# Altium schematic sheet QuadDrv405.SchDoc(QuadDrv405)
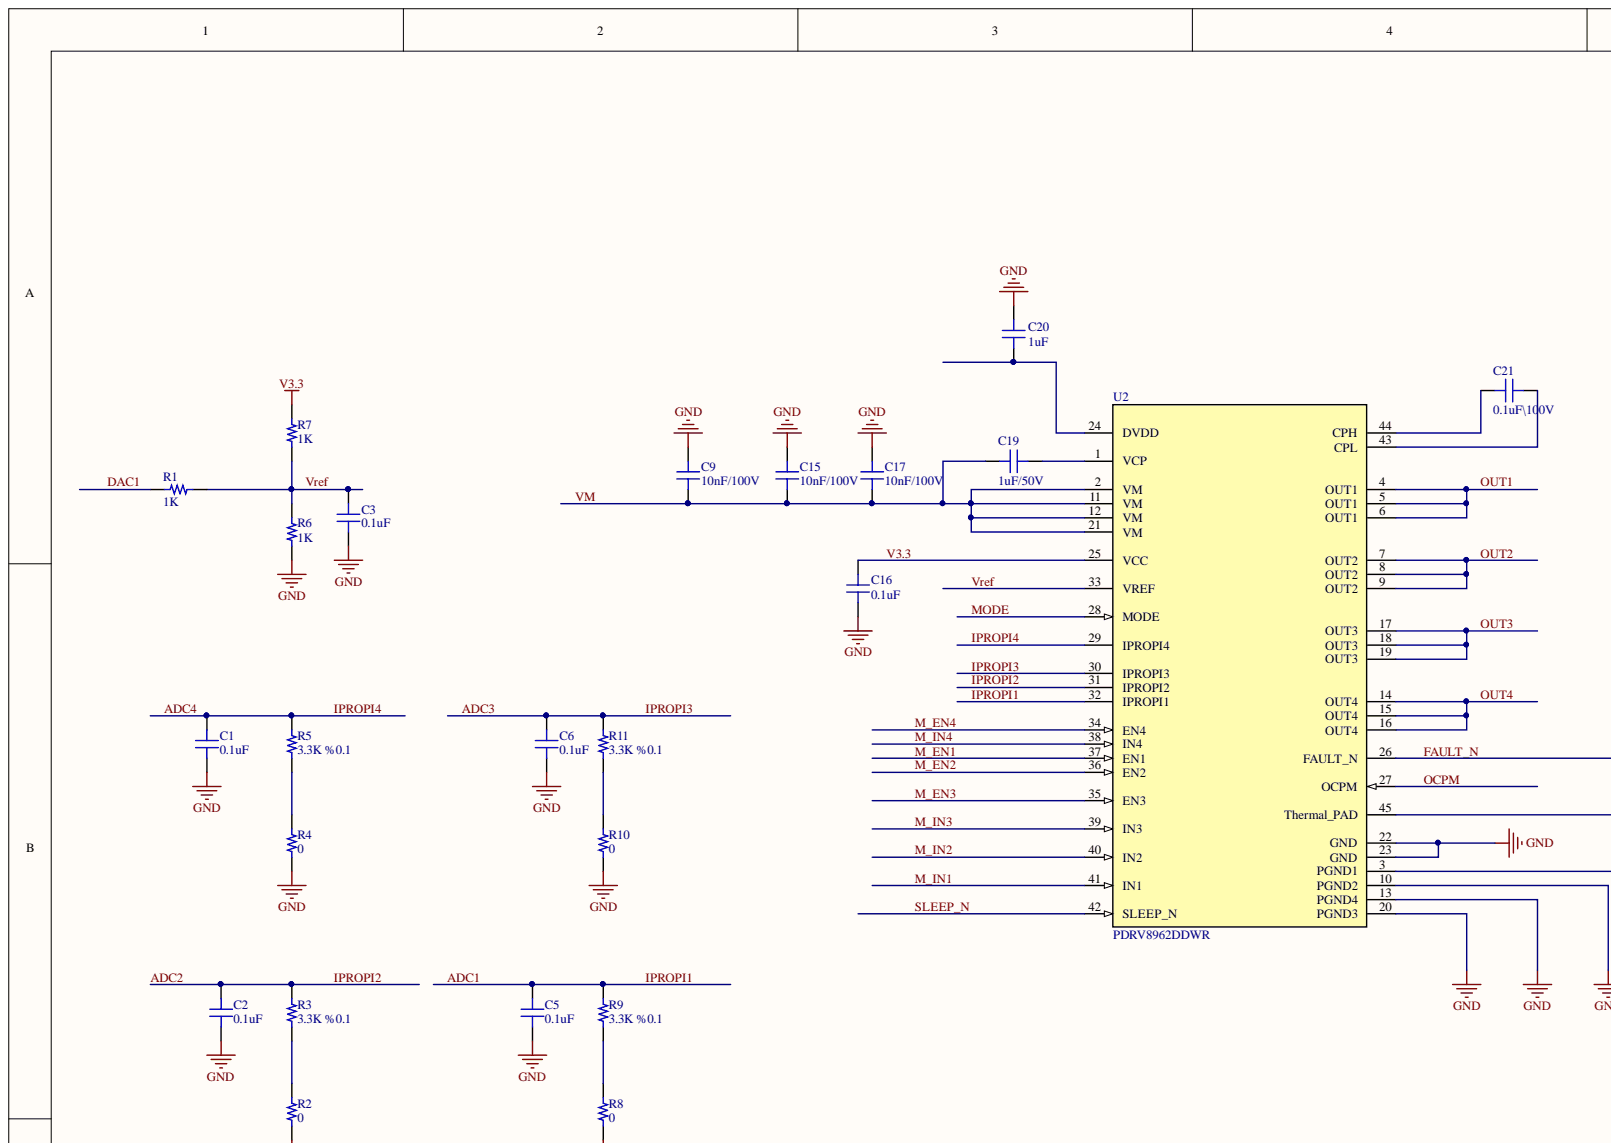
[diagram p0: QuadDrv405.SchDoc, top left]
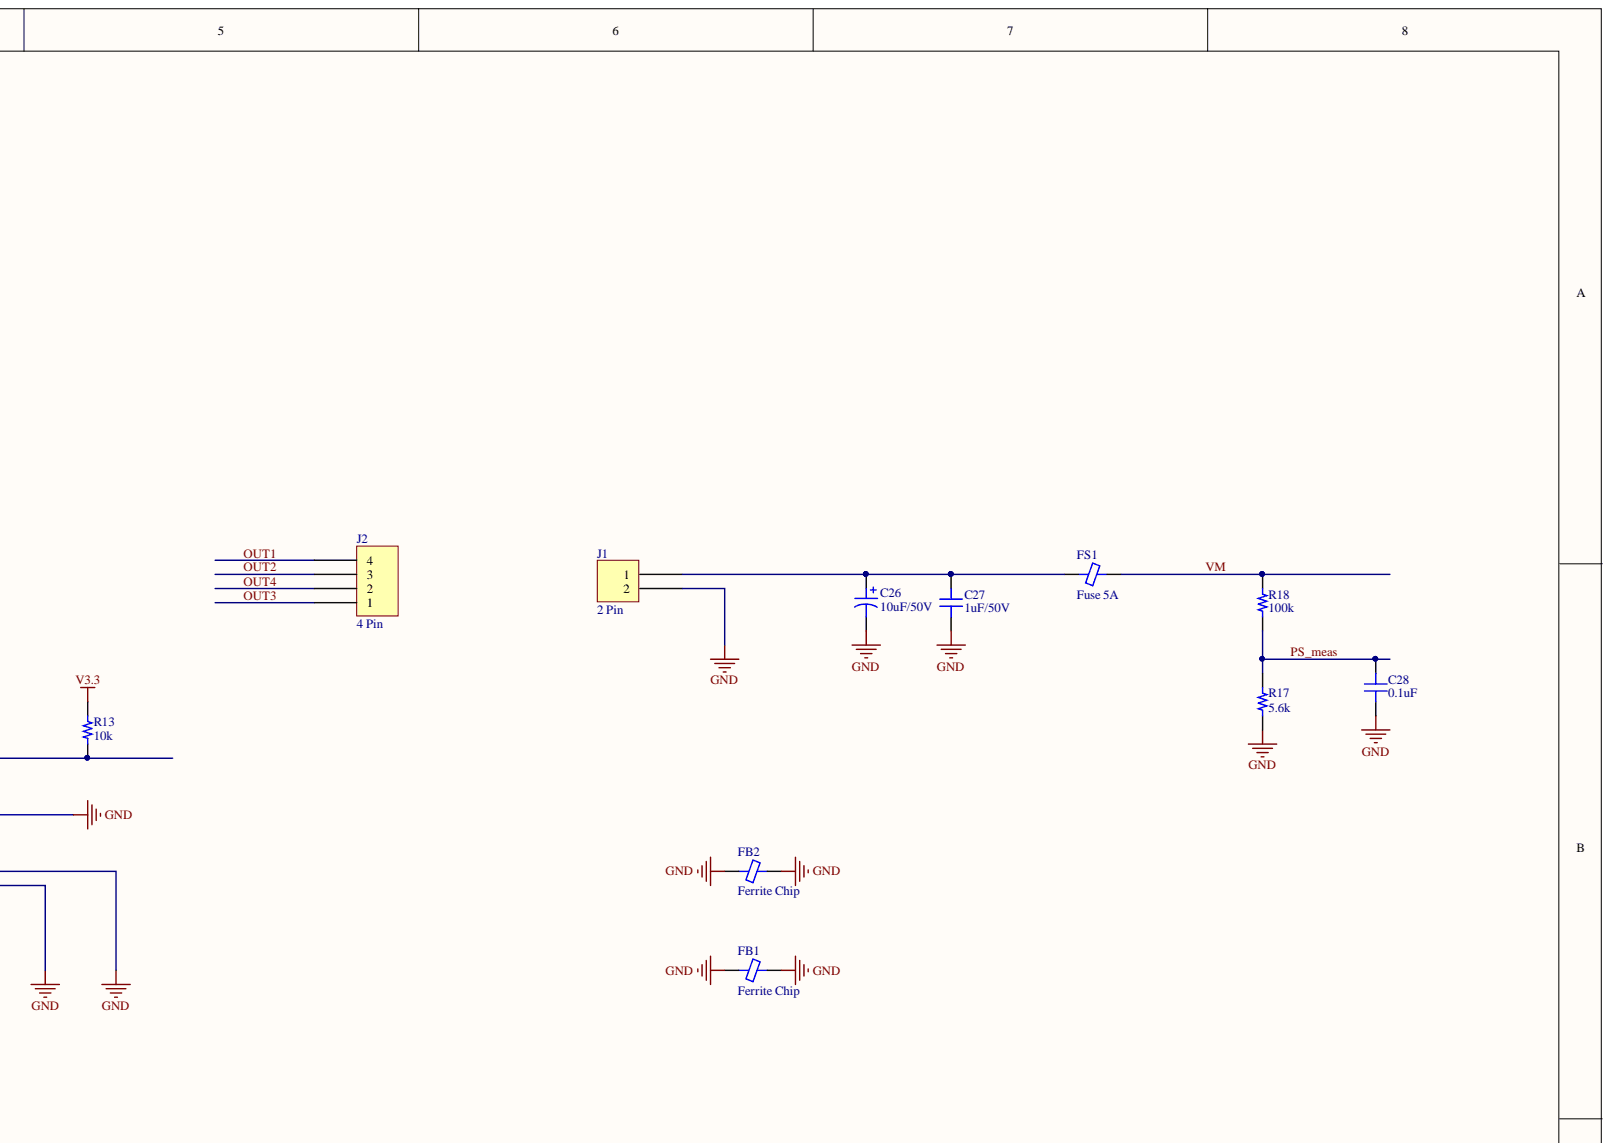
[diagram p1: QuadDrv405.SchDoc, top right]
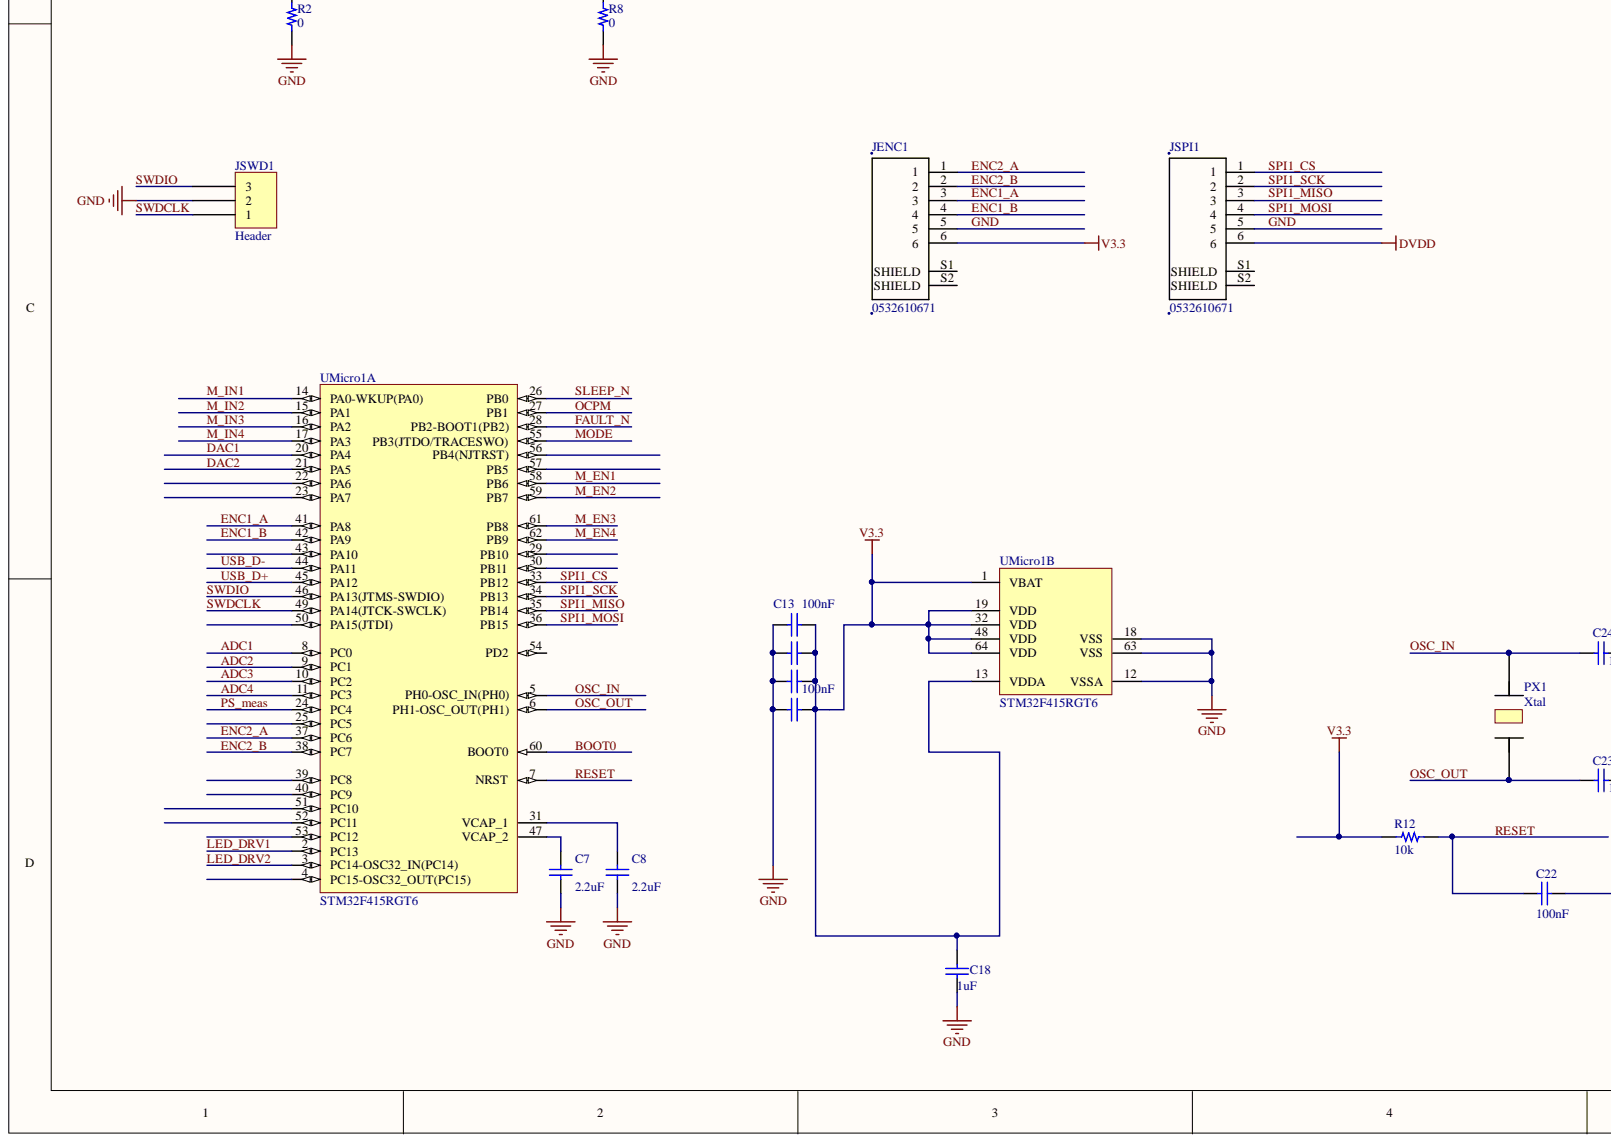
[diagram p2: QuadDrv405.SchDoc, bottom left]
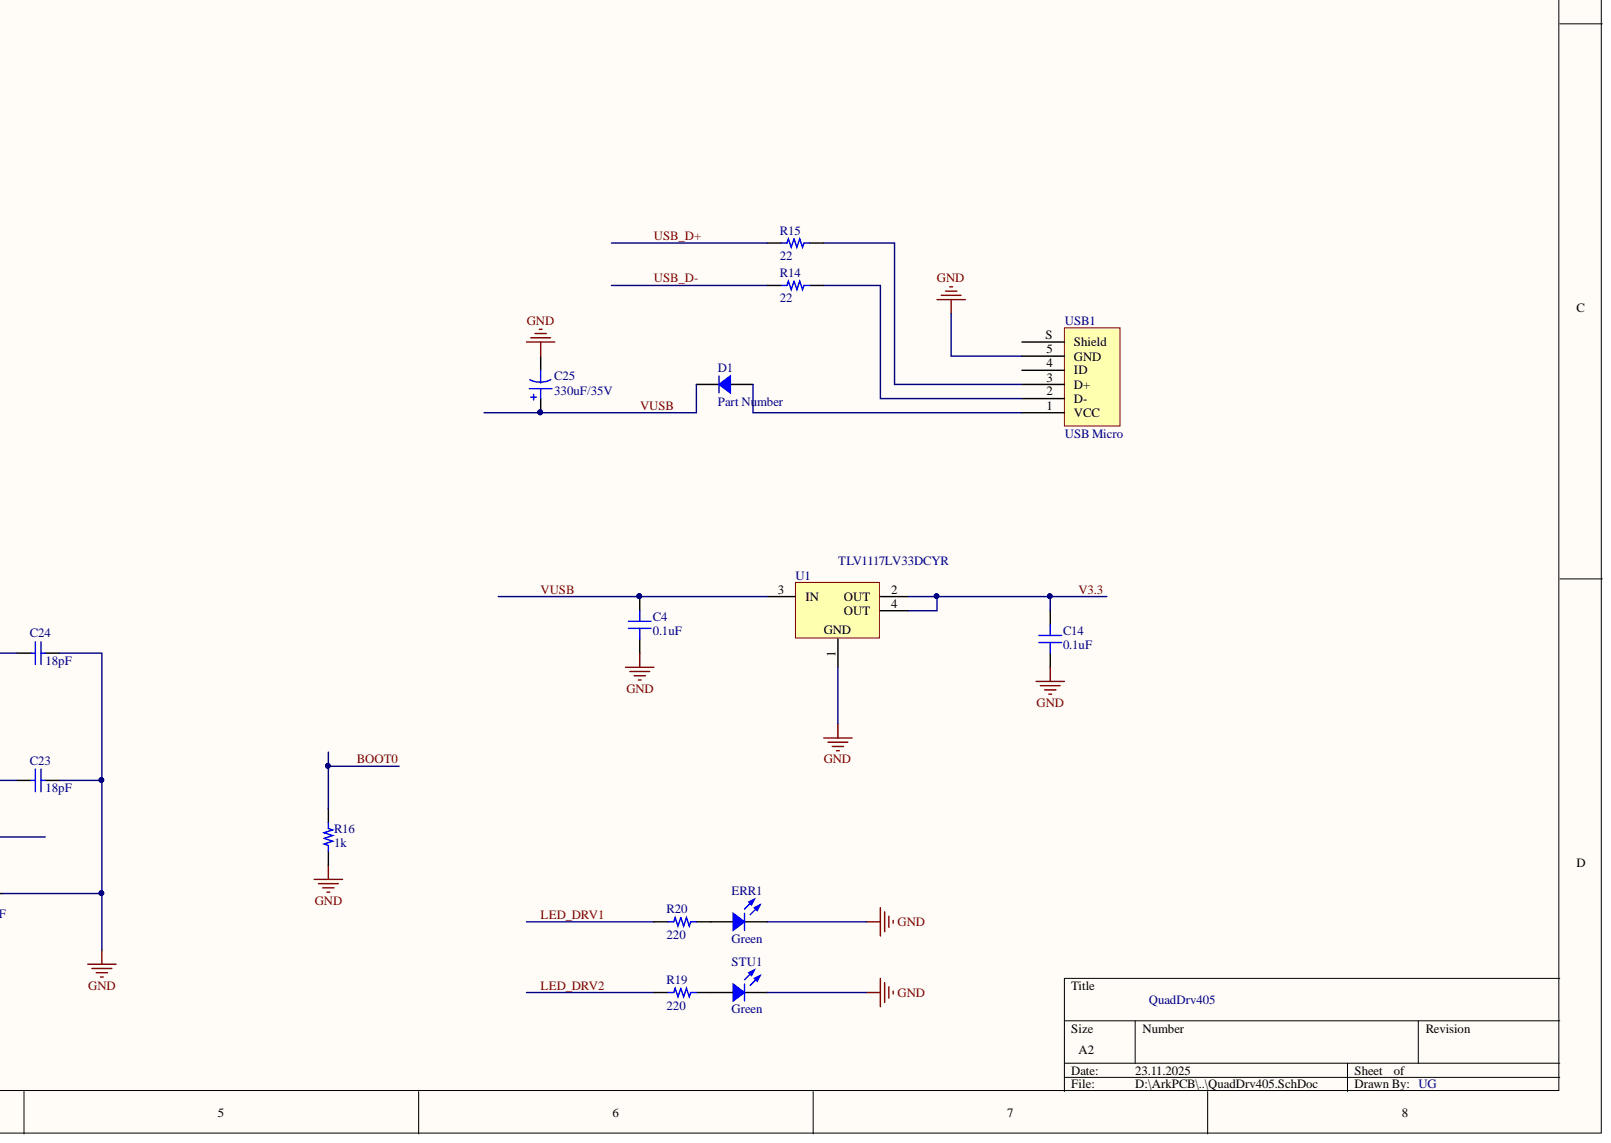
[diagram p3: QuadDrv405.SchDoc, bottom right]

## Parts
C1  0.1uF  [CAP 0603/1608 RF]
C2  0.1uF  [CAP 0603/1608 RF]
C3  0.1uF  [CAP 0603/1608 RF]
C4  0.1uF  [CAP 0603/1608 RF]
C5  0.1uF  [CAP 0603/1608 RF]
C6  0.1uF  [CAP 0603/1608 RF]
C7  2.2uF  [CAP 0603/1608]
C8  2.2uF  [CAP 0603/1608]
C9  10nF/100V  [CAP 0603/1608 RF]
C10  100nF  [CAP 0603/1608]
C11  100nF  [CAP 0603/1608]
C12  100nF  [CAP 0603/1608]
C13  100nF  [CAP 0603/1608]
C14  0.1uF  [CAP 0603/1608 RF]
C15  10nF/100V  [CAP 0603/1608 RF]
C16  0.1uF  [CAP 0603/1608 RF]
C17  10nF/100V  [CAP 0603/1608 RF]
C18  1uF  [CAP 0603/1608]
C19  1uF/50V  [CAP 0603/1608 RF]
C20  1uF  [CAP 0603/1608 RF]
C21  0.1uF\100V  [CAP 0603/1608 RF]
C22  100nF  [CAP 0603/1608]
C23  value 18pF  [CAP 0603/1608]  (hidden comment: 20pF)
C24  value 18pF  [CAP 0603/1608]  (hidden comment: 20pF)
C25  330uF/35V  [CAP ALUM 6.6MM]
C26  10uF/50V  [CAP ALUM 8.3MM]
C27  1uF/50V  [CAP 0603/1608 RF]
C28  0.1uF  [CAP 0603/1608 RF]
D1  Part Number  [DIO_RS1MLS]
ERR1  Green  [LED 0603/1608]
FB1  Ferrite Chip  [FER 0805/2012]
FB2  Ferrite Chip  [FER 0805/2012]
FS1  Fuse 5A  [RES 1206/3216 RF]
J1  2 Pin  [TE 282834-2]
J2  4 Pin  [TE 282834-4]
JENC1  0532610671  [*MOLEX_0532610671_0532610671(Primary)]
JSPI1  0532610671  [*MOLEX_0532610671_0532610671(Primary)]
JSWD1  Header  [HDR1X3]
PX1  Xtal  [ECS-120-18-33Q-DS]
R1  1K  [RES 0603/1608 RF]
R2  0  [RES 0603/1608 RF]
R3  3.3K %0.1  [RES 0603/1608 RF]
R4  0  [RES 0603/1608 RF]
R5  3.3K %0.1  [RES 0603/1608 RF]
R6  1K  [RES 0603/1608 RF]
R7  1K  [RES 0603/1608 RF]
R8  0  [RES 0603/1608 RF]
R9  3.3K %0.1  [RES 0603/1608 RF]
R10  0  [RES 0603/1608 RF]
R11  3.3K %0.1  [RES 0603/1608 RF]
R12  10k  [RES 0603/1608 RF]
R13  10k  [RES 0603/1608 RF]
R14  22  [RES 0603/1608 RF]
R15  22  [RES 0603/1608 RF]
R16  1k  [RES 0603/1608 RF]
R17  5.6k  [RES 0603/1608 RF]
R18  100k  [RES 0603/1608 RF]
R19  220  [RES 0603/1608 RF]
R20  220  [RES 0603/1608 RF]
STU1  Green  [LED 0603/1608]
U1  TLV1117LV33DCYR  [TI-DCY4_N]
U2  PDRV8962DDWR  [DDW0044E-IPC_A]
UMicro1  STM32F415RGT6  [STM-LQFP64_N]  (parts A,B)
USB1  USB Micro  [FCI USB 10033526-N3212LF]

## Nets
DVDD: JSPI1.6
ADC1: C5.2 R9.2 U2.32(IPROPI1) UMicro1.8(PC0)
ADC2: C2.2 R3.2 U2.31(IPROPI2) UMicro1.9(PC1)
ADC3: C6.2 R11.2 U2.30(IPROPI3) UMicro1.10(PC2)
ADC4: C1.2 R5.2 U2.29(IPROPI4) UMicro1.11(PC3)
BOOT0: R16.2 UMicro1.60(BOOT0)
DAC1: R1.2 UMicro1.20(PA4)
DAC2: UMicro1.21(PA5)
ENC1_A: JENC1.3 UMicro1.41(PA8)
ENC1_B: JENC1.4 UMicro1.42(PA9)
ENC2_A: JENC1.1 UMicro1.37(PC6)
ENC2_B: JENC1.2 UMicro1.38(PC7)
FAULT_N: R13.2 U2.26(FAULT_N) UMicro1.28(PB2-BOOT1(PB2))
GND: C1.1 C2.1 C3.1 C4.1 C5.1 C6.1 C7.1 C8.1 C9.2 C10.2 C11.2 C12.2 C13.2 C14.1 C15.2 C16.1 C17.2 C18.1 C20.2 C22.1 C23.2 C24.2 C25.2 C26.2 C27.1 C28.1 ERR1.2 FB1.1 FB1.2 FB2.1 FB2.2 J1.2 JENC1.5 JSPI1.5 JSWD1.2 PX1.2 PX1.4 R2.1 R4.1 R6.1 R8.2 R10.1 R16.1 R17.1 STU1.2 U1.1 U2.3(PGND1) U2.10(PGND2) U2.13(PGND4) U2.20(PGND3) U2.22(GND) U2.23(GND) U2.45(Thermal_PAD) UMicro1.12(VSSA) UMicro1.18(VSS) UMicro1.63(VSS) USB1.5(GND)
LED_DRV1: R20.1 UMicro1.2(PC13)
LED_DRV2: R19.1 UMicro1.3(PC14-OSC32_IN(PC14))
M_EN1: U2.37(EN1) UMicro1.58(PB6)
M_EN2: U2.36(EN2) UMicro1.59(PB7)
M_EN3: U2.35(EN3) UMicro1.61(PB8)
M_EN4: U2.34(EN4) UMicro1.62(PB9)
M_IN1: U2.41(IN1) UMicro1.14(PA0-WKUP(PA0))
M_IN2: U2.40(IN2) UMicro1.15(PA1)
M_IN3: U2.39(IN3) UMicro1.16(PA2)
M_IN4: U2.38(IN4) UMicro1.17(PA3)
MODE: U2.28(MODE) UMicro1.55(PB3(JTDO/TRACESWO))
OCPM: U2.27(OCPM) UMicro1.27(PB1)
OSC_IN: C24.1 PX1.3 UMicro1.5(PH0-OSC_IN(PH0))
OSC_OUT: C23.1 PX1.1 UMicro1.6(PH1-OSC_OUT(PH1))
OUT1: J2.4 U2.4(OUT1) U2.5(OUT1) U2.6(OUT1)
OUT2: J2.3 U2.7(OUT2) U2.8(OUT2) U2.9(OUT2)
OUT3: J2.1 U2.17(OUT3) U2.18(OUT3) U2.19(OUT3)
OUT4: J2.2 U2.14(OUT4) U2.15(OUT4) U2.16(OUT4)
PS_MEAS: C28.2 R17.2 R18.1 UMicro1.24(PC4)
RESET: C22.2 R12.2 UMicro1.7(NRST)
SLEEP_N: U2.42(SLEEP_N) UMicro1.26(PB0)
SPI1_CS: JSPI1.1 UMicro1.33(PB12)
SPI1_MISO: JSPI1.3 UMicro1.35(PB14)
SPI1_MOSI: JSPI1.4 UMicro1.36(PB15)
SPI1_SCK: JSPI1.2 UMicro1.34(PB13)
SWDCLK: JSWD1.1 UMicro1.49(PA14(JTCK-SWCLK))
SWDIO: JSWD1.3 UMicro1.46(PA13(JTMS-SWDIO))
USB_D+: R15.1 UMicro1.45(PA12)
USB_D-: R14.1 UMicro1.44(PA11)
V3.3: C10.1 C11.1 C12.1 C13.1 C14.2 C16.2 C18.2 JENC1.6 R7.2 R12.1 R13.1 U1.2(OUT) U1.4(OUT) U2.25(VCC) UMicro1.1(VBAT) UMicro1.13(VDDA) UMicro1.19(VDD) UMicro1.32(VDD) UMicro1.48(VDD) UMicro1.64(VDD)
VM: C9.1 C15.1 C17.1 C19.1 FS1.2 R18.2 U2.2(VM) U2.11(VM) U2.12(VM) U2.21(VM)
VREF: C3.2 R1.1 R6.2 R7.1 U2.33(VREF)
VUSB: C4.2 C25.1 D1.2 U1.3(IN)
NetC7_2: C7.2 UMicro1.47(VCAP_2)
NetC8_2: C8.2 UMicro1.31(VCAP_1)
NetC19_2: C19.2 U2.1(VCP)
NetC20_1: C20.1 U2.24(DVDD)
NetC21_1: C21.1 U2.44(CPH)
NetC21_2: C21.2 U2.43(CPL)
NetC26_1: C26.1 C27.2 FS1.1 J1.1
NetD1_1: D1.1 USB1.1(VCC)
NetERR1_1: ERR1.1 R20.2
NetR2_2: R2.2 R3.1
NetR4_2: R4.2 R5.1
NetR8_1: R8.1 R9.1
NetR10_2: R10.2 R11.1
NetR14_2: R14.2 USB1.2(D-)
NetR15_2: R15.2 USB1.3(D+)
NetR19_2: R19.2 STU1.1

Not connected: JENC1 S1(SHIELD) S2(SHIELD); JSPI1 S1(SHIELD) S2(SHIELD); UMicro1 4(PC15-OSC32_OUT(PC15)) 22(PA6) 23(PA7) 25(PC5) 29(PB10) 30(PB11) 39(PC8) 40(PC9) 43(PA10) 50(PA15(JTDI)) 51(PC10) 52(PC11) 53(PC12) 54(PD2) 56(PB4(NJTRST)) 57(PB5); USB1 4(ID) S(Shield)

## Library data of the parts
C1-C6 C9 C14-C17 C19-C21 C27 C28 = Capacitor: SMT Capacitor; Component Kind: Standard; Library Name: Passives.SchLib
  C1-C6 C14 C16 C28: Value: 0.1uF
  C9 C15 C17: Value: 10nF/100V
  C19 C27: Value: 1uF/50V
  C20: Value: 1uF
  C21: Value: 0.1uF\100V
C7 C8 = C0603: Ceramic Chip Capacitor - Standard; Manufacturer: Samsung Electro-Mechanics America, Inc.; Manufacturer Part Number: CL10B225KP8NNNC; Capacitance: 2.2µF; Note: Footprint corresponds to dimensions for bulk cassette packaging. This case size has tightened tolerances.; Temperature Coefficient: X7R; Tolerance: ±10%; Voltage - Rated: 10V; Applications: General Purpose; Category: Capacitors; Code_IPC: 1608 [0603]; Code_JEITA: 0603; Component Kind: Standard; ComponentLink1Description: Manufacturer Link; ComponentLink1URL: http://www.kemet.com/; ComponentLink2Description: Datasheet; ComponentLink2URL: http://www.kemet.com/kemet/web/homepage/kechome.nsf/vapubfilesname/F3102TA.pdf/$file/F3102TA.pdf; ComponentLink3Description: http://www.samsungsem.com/kr/support/product-search/mlcc/CL10B225KP8NNNC.jsp; ComponentLink3URL: http://www.samsungsem.com/kr/support/product-search/mlcc/CL10B225KP8NNNC.jsp; DatasheetDocument: Mar-2001; Features: -; Height - Seated (Max): -; LatestRevisionDate: 19-Mar-2004; LatestRevisionNote: Silkscreen size reduced.; Lead Spacing: -; Lead Style: -; Library Name: KEMET Chip Capacitor.IntLib; Mounting Type: Surface Mount, MLCC; Operating Temperature: -55°C ~ 125°C; Package / Case: 0603 (1608 Metric); PackageDocument: Mar-2001; PackageReference: 0603; Packaging: Cut Tape (CT); Pricing 1: 1=0.17, 10=0.115, 25=0.0864, 50=0.0662, 100=0.0546, 500=0.03852, 1000=0.03163 (USD); Published: 17-Jul-2002; Ratings: -; RoHS: RoHS Compliant; Signal Integrity: C0603; Simulation: CAP; Size / Dimension: 0.063" L x 0.031" W (1.60mm x 0.80mm); Stock 1: 54412; Supplier 1: Digi-Key; Supplier Part Number 1: 1276-1134-1-ND; Thickness (Max): 0.035" (0.90mm); Value: 2.2uF; Publisher: Altium Limited
C10-C13 C22 = C0603: Ceramic Chip Capacitor - Standard; Manufacturer: Samsung Electro-Mechanics America, Inc.; Manufacturer Part Number: CL10B104KB8SFNC; Capacitance: 0.10µF; Note: Footprint corresponds to dimensions for bulk cassette packaging. This case size has tightened tolerances.; Temperature Coefficient: X7R; Tolerance: ±10%; Voltage - Rated: 50V; Applications: Boardflex Sensitive; Category: Capacitors; Code_IPC: 1608 [0603]; Code_JEITA: 0603; Component Kind: Standard; ComponentLink1Description: Manufacturer Link; ComponentLink1URL: http://www.kemet.com/; ComponentLink2Description: Datasheet; ComponentLink2URL: http://www.kemet.com/kemet/web/homepage/kechome.nsf/vapubfilesname/F3102TA.pdf/$file/F3102TA.pdf; ComponentLink3Description: http://www.samsungsem.com/kr/support/product-search/mlcc/CL10B104KB8SFNC.jsp; ComponentLink3URL: http://www.samsungsem.com/kr/support/product-search/mlcc/CL10B104KB8SFNC.jsp; DatasheetDocument: Mar-2001; Features: Soft Termination; Height - Seated (Max): -; LatestRevisionDate: 19-Mar-2004; LatestRevisionNote: Silkscreen size reduced.; Lead Spacing: -; Lead Style: -; Library Name: KEMET Chip Capacitor.IntLib; Mounting Type: Surface Mount, MLCC; Operating Temperature: -55°C ~ 125°C; Package / Case: 0603 (1608 Metric); PackageDocument: Mar-2001; PackageReference: 0603; Packaging: Cut Tape (CT); Pricing 1: 1=0.1, 10=0.027, 25=0.0192, 50=0.0148, 100=0.0125, 500=0.00874, 1000=0.00681 (USD); Published: 17-Jul-2002; Ratings: -; RoHS: RoHS Compliant; Signal Integrity: C0603; Simulation: CAP; Size / Dimension: 0.063" L x 0.031" W (1.60mm x 0.80mm); Stock 1: 894545; Supplier 1: Digi-Key; Supplier Part Number 1: 1276-1936-1-ND; Thickness (Max): 0.035" (0.90mm); Value: 100nF; Publisher: Altium Limited
C18 = C0603: Ceramic Chip Capacitor - Standard; Manufacturer: Samsung Electro-Mechanics America, Inc.; Manufacturer Part Number: CL10B105KA8NNNC; Capacitance: 1µF; Note: Footprint corresponds to dimensions for bulk cassette packaging. This case size has tightened tolerances.; Temperature Coefficient: X7R; Tolerance: ±10%; Voltage - Rated: 25V; Applications: General Purpose; Category: Capacitors; Code_IPC: 1608 [0603]; Code_JEITA: 0603; Component Kind: Standard; ComponentLink1Description: Manufacturer Link; ComponentLink1URL: http://www.kemet.com/; ComponentLink2Description: Datasheet; ComponentLink2URL: http://www.kemet.com/kemet/web/homepage/kechome.nsf/vapubfilesname/F3102TA.pdf/$file/F3102TA.pdf; ComponentLink3Description: http://media.digikey.com/pdf/Data%20Sheets/Samsung%20PDFs/CL10B105KA8NNNC_spec.pdf; ComponentLink3URL: http://media.digikey.com/pdf/Data%20Sheets/Samsung%20PDFs/CL10B105KA8NNNC_spec.pdf; DatasheetDocument: Mar-2001; Features: -; Height - Seated (Max): -; LatestRevisionDate: 19-Mar-2004; LatestRevisionNote: Silkscreen size reduced.; Lead Spacing: -; Lead Style: -; Library Name: KEMET Chip Capacitor.IntLib; Mounting Type: Surface Mount, MLCC; Operating Temperature: -55°C ~ 125°C; Package / Case: 0603 (1608 Metric); PackageDocument: Mar-2001; PackageReference: 0603; Packaging: Cut Tape (CT); Pricing 1: 1=0.1, 10=0.068, 25=0.0484, 50=0.037, 100=0.0314, 500=0.02194, 1000=0.0171 (USD); Published: 17-Jul-2002; Ratings: -; RoHS: RoHS Compliant; Signal Integrity: C0603; Simulation: CAP; Size / Dimension: 0.063" L x 0.031" W (1.60mm x 0.80mm); Stock 1: 1481927; Supplier 1: Digi-Key; Supplier Part Number 1: 1276-1184-1-ND; Thickness (Max): 0.035" (0.90mm); Value: 1uF; Publisher: Altium Limited
C23 C24 = C0603: Ceramic Chip Capacitor - Standard; Manufacturer: Samsung Electro-Mechanics America, Inc.; Manufacturer Part Number: CL10C200JB8NNNC; Note: Footprint corresponds to dimensions for bulk cassette packaging. This case size has tightened tolerances.; Temperature Coefficient: C0G, NP0; Tolerance: ±5%; Voltage - Rated: 50V; Applications: General Purpose; Capacitance: 20pF; Category: Capacitors; Code_IPC: 1608 [0603]; Code_JEITA: 0603; Component Kind: Standard; ComponentLink1Description: Manufacturer Link; ComponentLink1URL: http://www.kemet.com/; ComponentLink2Description: Datasheet; ComponentLink2URL: http://www.kemet.com/kemet/web/homepage/kechome.nsf/vapubfilesname/F3102TA.pdf/$file/F3102TA.pdf; ComponentLink3Description: http://www.samsungsem.com/kr/support/product-search/mlcc/CL10C200JB8NNNC.jsp; ComponentLink3URL: http://www.samsungsem.com/kr/support/product-search/mlcc/CL10C200JB8NNNC.jsp; DatasheetDocument: Mar-2001; Features: -; Height - Seated (Max): -; LatestRevisionDate: 19-Mar-2004; LatestRevisionNote: Silkscreen size reduced.; Lead Spacing: -; Lead Style: -; Library Name: KEMET Chip Capacitor.IntLib; Mounting Type: Surface Mount, MLCC; Operating Temperature: -55°C ~ 125°C; Package / Case: 0603 (1608 Metric); PackageDocument: Mar-2001; PackageReference: 0603; Packaging: Cut Tape (CT); Pricing 1: 1=0.1, 10=0.031, 25=0.0216, 50=0.0166, 100=0.0141, 500=0.00986, 1000=0.00768 (USD); Published: 17-Jul-2002; Ratings: -; RoHS: RoHS Compliant; Signal Integrity: C0603; Simulation: CAP; Size / Dimension: 0.063" L x 0.031" W (1.60mm x 0.80mm); Stock 1: 259816; Supplier 1: Digi-Key; Supplier Part Number 1: 1276-1187-1-ND; Thickness (Max): 0.035" (0.90mm); Publisher: Altium Limited
C25 C26 = Polarised Capacitor: SMT Polarised Capacitor; Component Kind: Standard; Library Name: Passives.SchLib
  C25: Value: 330uF/35V
  C26: Value: 10uF/50V
D1 = Diode: Rectifier Diode; Component Kind: Standard; Library Name: Diodes.SchLib
ERR1 STU1 = LED: Chip LED; Component Kind: Standard; Library Name: Diodes.SchLib; Value: Green
FB1 FB2 FS1 = Ferrite Chip: SMT Ferrite Chip; Component Kind: Standard; Library Name: Passives.SchLib
JENC1 JSPI1 = 0532610671: 1.25mm _.049_ Pitch PicoBlade Header, Surface Mount, Right Angle, Lead-free, 6 Circuits; MF: Molex; Availability: Unavailable; Component Kind: Standard; Library Name: 0532610671.SchLib; MP: 0532610671; Package: None; Price: None; SnapEDA_Link: https://www.snapeda.com/parts/0532610671/Molex/view-part/?ref=snap
JSWD1 = 3 Pin: Component Kind: Standard; Library Name: Connectors.SchLib
PX1 = Xtal-SMD: Crystal SMD; Component Kind: Standard; LatestRevisionDate: 17-Jul-2002; LatestRevisionNote: Re-released for DXP Platform.; Library Name: UCANKAYA.SchLib; Publisher: Altium Limited
R1-R20 = Resistor: SMT Resistor; Component Kind: Standard; Library Name: Passives.SchLib
  R1 R6 R7: Value: 1K
  R2 R4 R8 R10: Value: 0
  R3 R5 R9 R11: Value: 3.3K %0.1
  R12 R13: Value: 10k
  R14 R15: Value: 22
  R16: Value: 1k
  R17: Value: 5.6k
  R18: Value: 100k
  R19 R20: Value: 220
U1 = TLV1117LV33DCYR: Single Output LDO, 1 A, Fixed 3.3 V Output, 2 to 5.5 V Input, 4-pin SOT-223 (DCY), -40 to 125 degC, Green (RoHS & no Sb/Br); Manufacturer: Texas Instruments; Accuracy(%): 2; Fixed Output Options(V): 3.3; Iout(Max)(A): 1; Iq(Typ)(mA): 0.05; SubFamily: Single Channel LDO; Vdo(Typ)(mV): 1200; Vin(Max)(V): 5.5; Code_JEDEC: TO-261; Component Kind: Standard; ComponentLink1Description: Manufacturer URL; ComponentLink1URL: http://www.ti.com/; ComponentLink2Description: Datasheet; ComponentLink2URL: http://www.ti.com/general/docs/lit/getliterature.tsp?genericPartNumber=TLV1117LV33&fileType=pdf; ComponentLink3Description: Package Specification; ComponentLink3URL: http://www.ti.com/litv/pdf/mpds094a; DatasheetVersion: SBVS160A; Eco-Plan: Green (RoHS & no Sb/Br); Library Name: TI Power Management Linear Regulator Single Channel LDO (400-7500mA).IntLib; Mounting Technology: Surface Mount; PackageDescription: 4-Pin Plastic Small Outline, Body 3.5 x 6.5 mm, Pitch 2.3 mm; PackageReference: DCY4; PackageVersion: 4202506/B; PartNumber: TLV1117LV33DCYR; Supplier 1: Digi-Key; Supplier 2: Digi-Key; Supplier 3: Digi-Key; Supplier 4: Newark; Supplier 5: Mouser; Supplier Part Number 1: 296-28778-2-ND; Supplier Part Number 2: 296-28778-1-ND; Supplier Part Number 3: 296-28778-6-ND; Supplier Part Number 4: 48T4644; Supplier Part Number 5: 595-TLV1117LV33DCYR; Vin(Min)(V): 2; Vout Adj(Max)(V): 3.3; Vout Adj(Min)(V): 3.3
U2 = PDRV8962DDWR: No Description Available; Mfr_Name: Texas Instruments; Component Kind: Standard; Library Name: PDRV8962DDWR.SchLib; RefDes: RefDes; TYPE: TYPE
UMicro1 = STM32F415RGT6: ARM Cortex-M4 32-bit MCU+FPU, 210 DMIPS, 1024 kB Flash, 192 kB Internal RAM, 51 I/Os, 64-pin LQFP, -40 to 85 degC, Tray; Manufacturer: STMicroelectronics; D/A Converter: 2x12-bit; FLASH Size (Prog) (kB): 1024; I/Os (High Current): 51; Internal RAM Size (kB): 192; Operating Frequency(F) (Processor speed) (MHz): 168; Supply Voltage(Vcc) max (V): 3.6; Supply Voltage(Vcc) min (V): 1.8; Component Kind: Standard; ComponentLink1Description: Manufacturer URL; ComponentLink1URL: http://www.st.com/web/en/home.html; ComponentLink2Description: Datasheet; ComponentLink2URL: http://www.st.com/st-web-ui/static/active/en/resource/technical/document/datasheet/DM00035129.pdf; ComponentLink3Description: Package Specification; ComponentLink3URL: http://www.st.com/st-web-ui/static/active/en/resource/technical/document/datasheet/DM00037051.pdf; Core: ARM Cortex-M4; DatasheetVersion: Rev. 4; Library Name: STMicroelectronics STM32 F4.IntLib; Mounting Technology: Surface Mount; PackageDescription: 64-Pin Low Profile Quad Flat Package, 10 x 10 mm Body, 0.5 mm Pitch; PackageReference: LQFP64; PackageVersion: Rev. 4, 06/2013; Packing: Tray; PartNumber: STM32F415RGT6; Supplier 1: Digi-Key; Supplier 2: Farnell; Supplier 3: Newark; Supplier 4: Mouser; Supplier Part Number 1: 497-11907-ND; Supplier Part Number 2: 2064370; Supplier Part Number 3: 73T2335; Supplier Part Number 4: 511-STM32F415RGT6
USB1 = USB 2.0 Type B Micro: Component Kind: Standard; Library Name: Connectors.SchLib
J1 = 2 Pin: Component Kind: Standard; Library Name: Connectors.SchLib
J2 = 4 Pin: Component Kind: Standard; Library Name: Connectors.SchLib
